# Altium schematic sheet LDO.SchDoc(U_LDO)
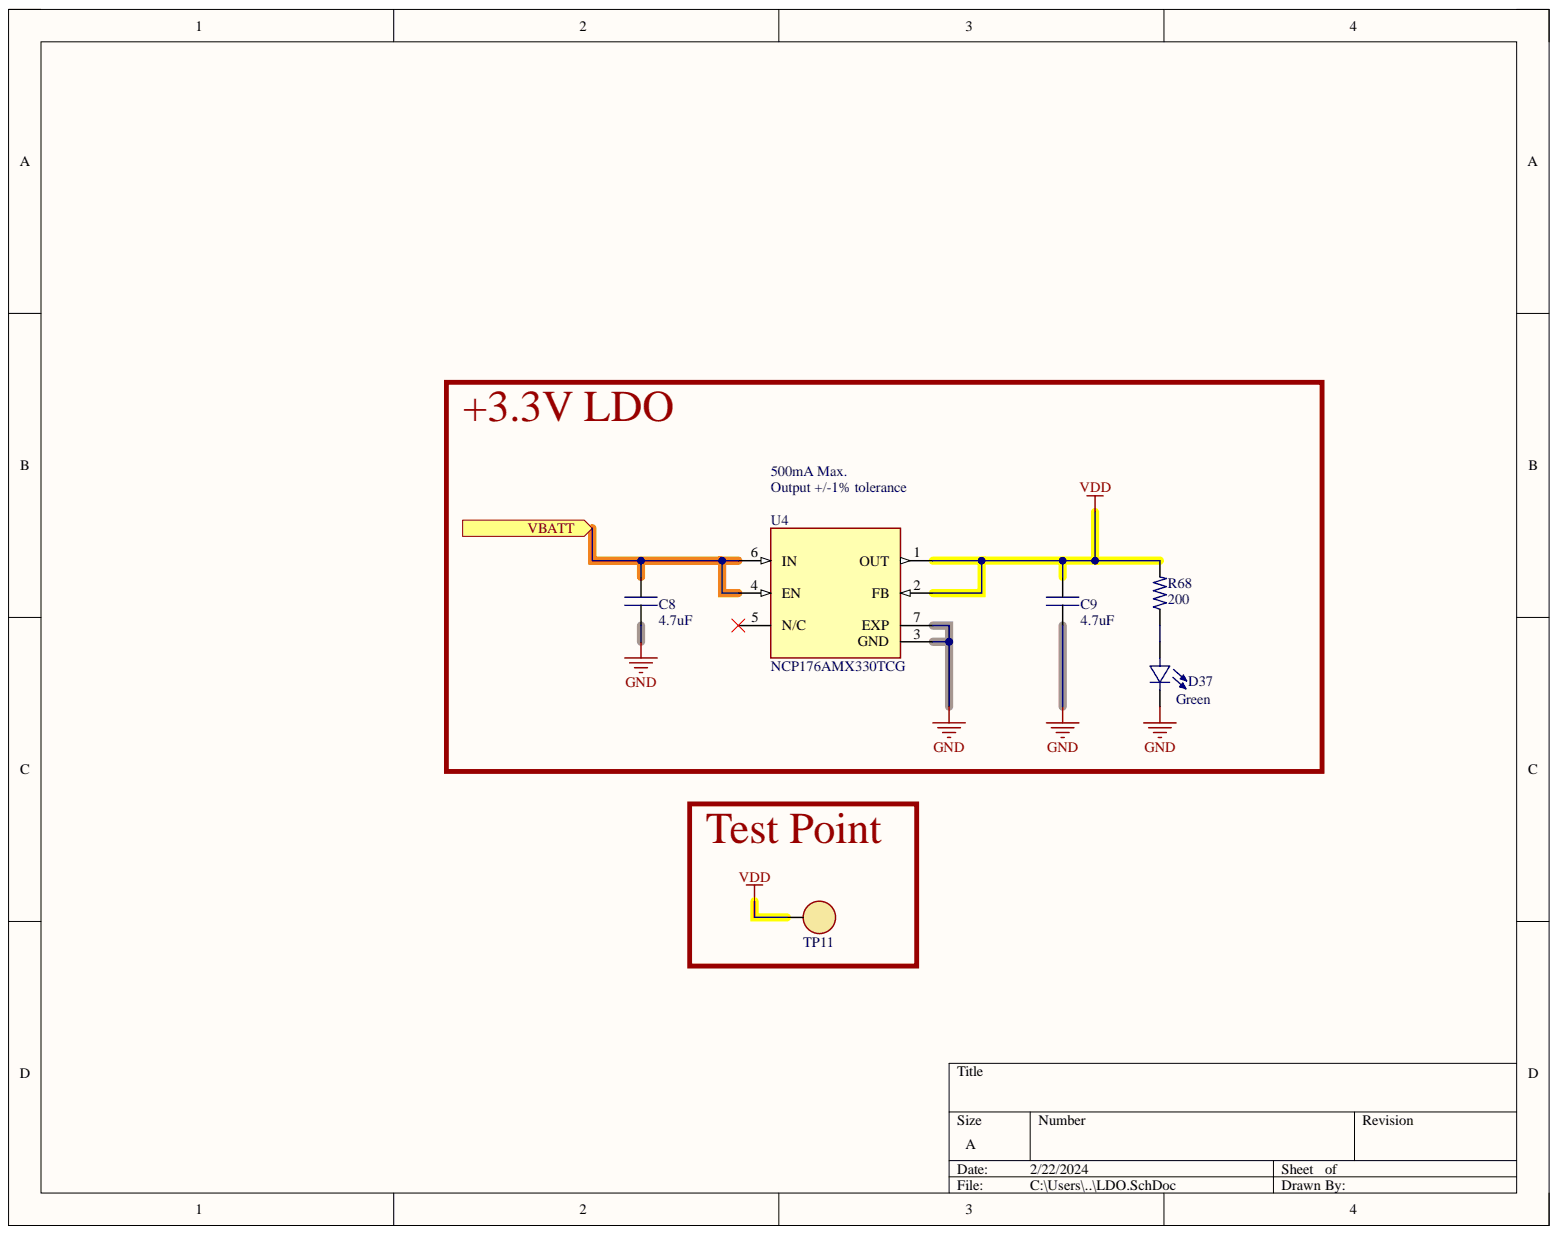
[diagram p0: LDO.SchDoc]

## Parts
C8  value 4.7uF  (hidden comment: CAP)
C9  value 4.7uF  (hidden comment: CAP)
D37  Color: Green  [0603-LED]  (hidden comment: LED - single)
R68  value 200  [0402R]  (hidden comment: RES)
TP11  RH-5019  [TP - RH-5019]  (value not drawn)
U4  NCP176AMX330TCG  [6-XDFN]

## Ports
VBATT

## Nets
GND: C8.1 C9.1 D37.2 U4.3(GND) U4.7(EXP)
VDD: C9.2 R68.2 TP11.1 U4.1(OUT) U4.2(FB)
VBATT: C8.2 U4.4(EN) U4.6(IN) ; port VBATT
NetD37_1: D37.1 R68.1
NetU4_5: U4.5(N/C)

## Library data of the parts
C8 C9 = CAP: Capacitor; Component Kind: Standard; Library Name: PressurePulse.SchLib
D37 = LED - single: LED; Value: SZYY0603YG; Component Kind: Standard; JLCPCB PN: C434423; Library Name: PressurePulse.SchLib
R68 = RES: Resistor; Component Kind: Standard; Library Name: PressurePulse.SchLib; Type: SMD
TP11 = TEST POINT: MFR: ronghe; Component Kind: Standard; JLCPCB PN: C5199800; Library Name: PressurePulse.SchLib; MFR PN: RH-5019; Value: RH-5019
U4 = LDO 3.3V: LDO REGULATOR; MFR: onsemi; Component Kind: Standard; JLCPCB PN: C233363; Library Name: PressurePulse.SchLib; MFR PN: NCP176AMX330TCG; Value: NCP176AMX330TCG
